# Altium schematic sheet [15] PWR.SchDoc(BLK4)
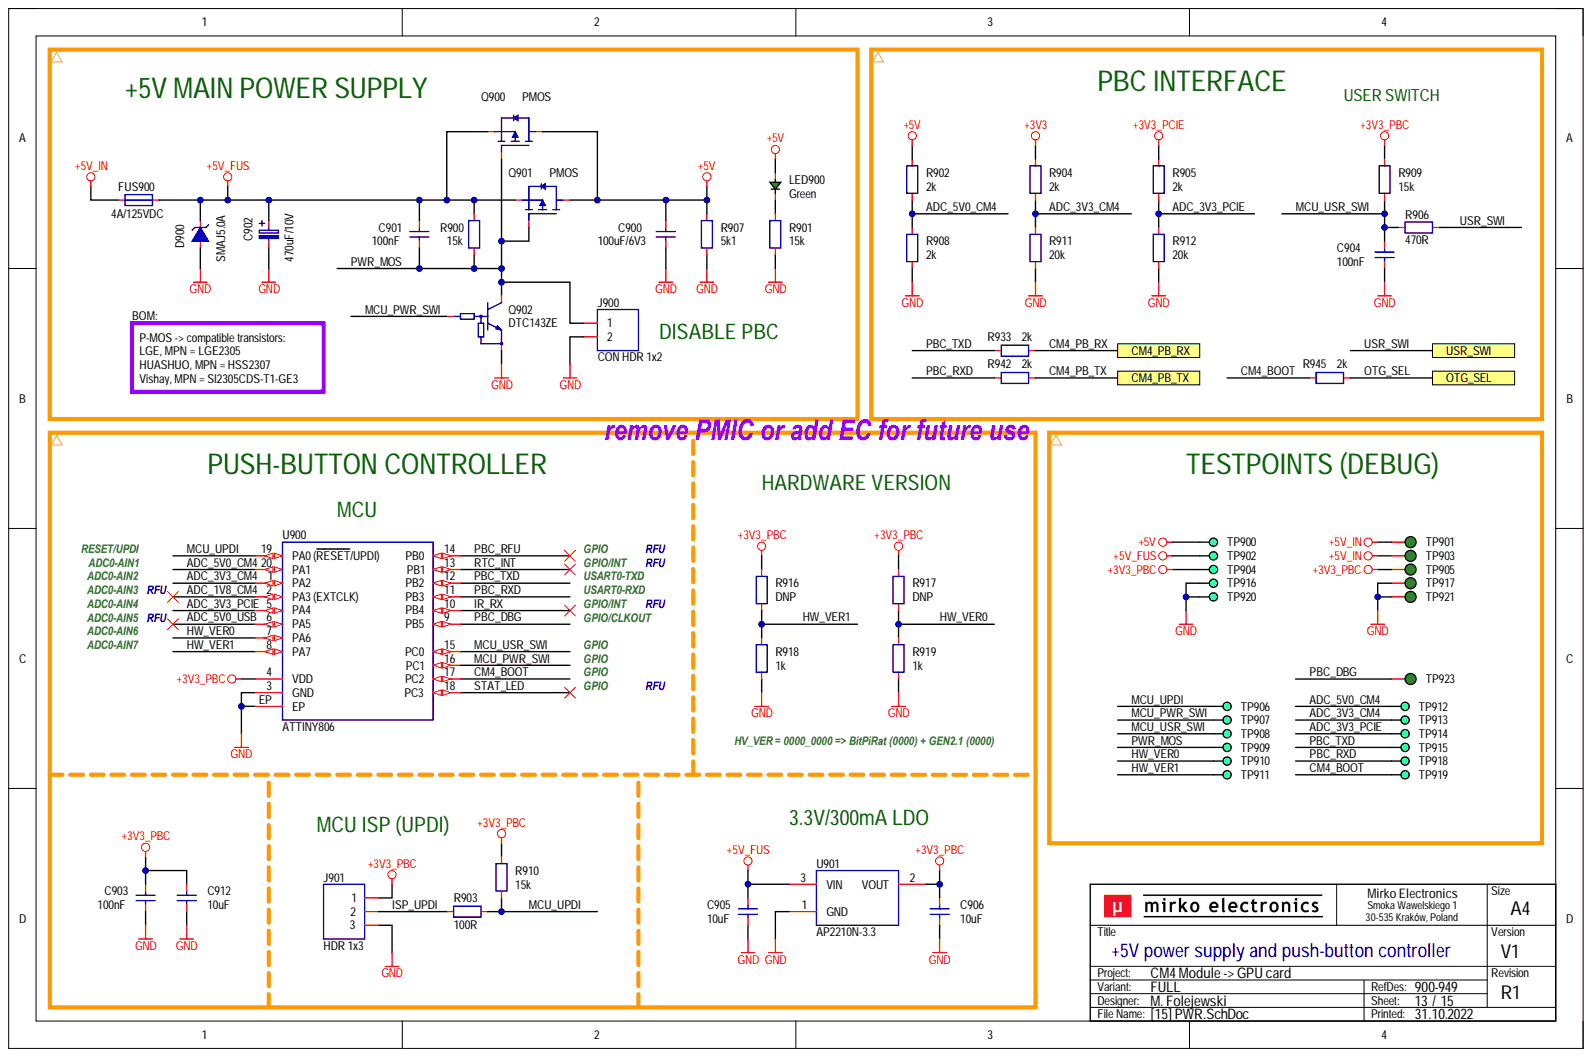
[diagram p0: [15] PWR.SchDoc]

## Parts
C900  100uF/6V3  [CAP_1206_R]
C901  100nF  [CAP_0603_R]
C902  470uF/10V  [CAP_SMD_SIZE_D8]
C903  100nF  [CAP_0603_R]
C904  100nF  [CAP_0603_R]
C905  10uF  [CAP_0603_R]
C906  10uF  [CAP_0603_R]
C912  10uF  [CAP_0603_R]
D900  SMAJ5.0A  [DIO_SMA_UNI_R]
FUS900  4A/125VDC  [FUS_2410_R]
J900  CON HDR 1x2  [CON_HDR_P254_1X02_STR]
J901  HDR 1x3  [CON_HDR_P254_1X03_STR]
LED900  Green  [LED_0805_GRN_R]
Q900  PMOS  [PKG_SOT23-3_TO236]
Q901  PMOS  [PKG_SOT23-3_TO236]
Q902  DTC143ZE  [PKG_SOT523_SC75_SOT416_P100_R]
R900  15k  [RES_0603_R]
R901  15k  [RES_0603_R]
R902  2k  [RES_0603_R]
R903  100R  [RES_0603_R]
R904  2k  [RES_0603_R]
R905  2k  [RES_0603_R]
R906  470R  [RES_0603_R]
R907  5k1  [RES_0603_R]
R908  2k  [RES_0603_R]
R909  15k  [RES_0603_R]
R910  15k  [RES_0603_R]
R911  20k  [RES_0603_R]
R912  20k  [RES_0603_R]
R916  DNP  [RES_0603_R]
R917  DNP  [RES_0603_R]
R918  1k  [RES_0603_R]
R919  1k  [RES_0603_R]
R933  2k  [RES_0603_R]
R942  2k  [RES_0603_R]
R945  2k  [RES_0603_R]
TP900  DEBUG  [PCB_TP_DBG_50]  (value not drawn)  (no BOM)
TP901  ICT  [PCB_TP_ICT_100]  (value not drawn)  (no BOM)
TP902  DEBUG  [PCB_TP_DBG_50]  (value not drawn)  (no BOM)
TP903  ICT  [PCB_TP_ICT_100]  (value not drawn)  (no BOM)
TP904  DEBUG  [PCB_TP_DBG_50]  (value not drawn)  (no BOM)
TP905  ICT  [PCB_TP_ICT_100]  (value not drawn)  (no BOM)
TP906  DEBUG  [PCB_TP_DBG_50]  (value not drawn)  (no BOM)
TP907  DEBUG  [PCB_TP_DBG_50]  (value not drawn)  (no BOM)
TP908  DEBUG  [PCB_TP_DBG_50]  (value not drawn)  (no BOM)
TP909  DEBUG  [PCB_TP_DBG_50]  (value not drawn)  (no BOM)
TP910  DEBUG  [PCB_TP_DBG_50]  (value not drawn)  (no BOM)
TP911  DEBUG  [PCB_TP_DBG_50]  (value not drawn)  (no BOM)
TP912  DEBUG  [PCB_TP_DBG_50]  (value not drawn)  (no BOM)
TP913  DEBUG  [PCB_TP_DBG_50]  (value not drawn)  (no BOM)
TP914  DEBUG  [PCB_TP_DBG_50]  (value not drawn)  (no BOM)
TP915  DEBUG  [PCB_TP_DBG_50]  (value not drawn)  (no BOM)
TP916  DEBUG  [PCB_TP_DBG_50]  (value not drawn)  (no BOM)
TP917  ICT  [PCB_TP_ICT_100]  (value not drawn)  (no BOM)
TP918  DEBUG  [PCB_TP_DBG_50]  (value not drawn)  (no BOM)
TP919  DEBUG  [PCB_TP_DBG_50]  (value not drawn)  (no BOM)
TP920  DEBUG  [PCB_TP_DBG_50]  (value not drawn)  (no BOM)
TP921  ICT  [PCB_TP_ICT_100]  (value not drawn)  (no BOM)
TP923  ICT  [PCB_TP_ICT_100]  (value not drawn)  (no BOM)
U900  ATTINY806  [ICC_QFN20_300X300X90_P40_EP_R]
U901  AP2210N-3.3  [PKG_SOT23-3L_SC59_P190_R]

## Ports
CM4_PB_RX, CM4_PB_TX, OTG_SEL, USR_SWI

## Nets
+3V3: R904.1
+3V3_PBC: C903.2 C906.2 C912.2 J901.1 R909.2 R910.2 R916.2 R917.2 TP904.1 TP905.1 U900.4(VDD) U901.2
+3V3_PCIE: R905.1
+5V: C900.2 LED900.2 Q900.3 Q901.3 R902.1 R907.1 TP900.1
+5V_FUS: C901.2 C902.2 C905.2 D900.1 FUS900.2 Q900.2 Q901.2 R900.2 TP902.1 U901.3
+5V_IN: FUS900.1 TP901.1 TP903.1
GND: C900.1 C902.1 C903.1 C904.1 C905.1 C906.1 C912.1 D900.2 J900.2 J901.3 Q902.2 R901.1 R907.2 R908.2 R911.1 R912.1 R918.1 R919.1 TP916.1 TP917.1 TP920.1 TP921.1 U900.3(GND) U900.EP U901.1
ADC_1V8_CM4: U900.2(PA3_(EXTCLK))
ADC_3V3_CM4: R904.2 R911.2 TP913.1 U900.1(PA2)
ADC_3V3_PCIE: R905.2 R912.2 TP914.1 U900.5(PA4)
ADC_5V0_CM4: R902.2 R908.1 TP912.1 U900.20(PA1)
ADC_5V0_USB: U900.6(PA5)
CM4_BOOT: R945.2 TP919.1 U900.17(PC2)
CM4_PB_RX: R933.2 ; port CM4_PB_RX
CM4_PB_TX: R942.2 ; port CM4_PB_TX
HW_VER0: R917.1 R919.2 TP910.1 U900.7(PA6)
HW_VER1: R916.1 R918.2 TP911.1 U900.8(PA7)
IR_RX: U900.10(PB4)
ISP_UPDI: J901.2 R903.1
MCU_PWR_SWI: Q902.1 TP907.1 U900.16(PC1)
MCU_UPDI: R903.2 R910.1 TP906.1 U900.19(PA0_(RESET/UPDI))
MCU_USR_SWI: C904.2 R906.2 R909.1 TP908.1 U900.15(PC0)
OTG_SEL: R945.1 ; port OTG_SEL
PBC_DBG: TP923.1 U900.9(PB5)
PBC_RFU: U900.14(PB0)
PBC_RXD: R942.1 TP918.1 U900.11(PB3)
PBC_TXD: R933.1 TP915.1 U900.12(PB2)
PWR_MOS: C901.1 J900.1 Q900.1 Q901.1 Q902.3 R900.1 TP909.1
RTC_INT: U900.13(PB1)
STAT_LED: U900.18(PC3)
USR_SWI: R906.1 ; port USR_SWI
NetLED900_1: LED900.1 R901.2

## Library data of the parts
C900 = CAP-0025: Capacitor; MLCC; GENERIC; TBD; TBD; TBD; TBD; SMD; 1206 (3216);; Database Table Name: CAPACITOR$; Component Kind: Standard; Component Subtype: MLCC; Component Type: Capacitor; Manufacturer: GENERIC; Mounting Type: SMD; MPN: GENERIC; Package (Case): 1206 (3216); Supplier: ?; Value: 100uF/6V3; Library Name: MirFol_db_local.DbLib
C901 C903 C904 = CAP-0008: Capacitor; MLCC; 100nF; TBD; TBD; TBD; TBD; SMD; 0603 (1608);; Database Table Name: CAPACITOR$; Component Kind: Standard; Component Subtype: MLCC; Component Type: Capacitor; Manufacturer: TBD; Mounting Type: SMD; MPN: TBD; Package (Case): 0603 (1608); Supplier: ?; Value: 100nF; Library Name: MirFol_db_local.DbLib
C902 = CAP_POL_2P_NP: Capacitor; Polarized;; Manufacturer: Panasonic; MPN: EEEFTA471XAP; Component Subtype: Electrolytic; Package (Case): SMD SIZE D8; Component Kind: Standard; Component Type: Capacitor; Mounting Type: SMD; Value: 470uF/10V; Library Name: MirFol_lib.SchLib
C905 C906 C912 = CAP-0023: Capacitor; MLCC; GENERIC; TBD; TBD; TBD; TBD; SMD; 0603 (1608);; Database Table Name: CAPACITOR$; Component Kind: Standard; Component Subtype: MLCC; Component Type: Capacitor; Manufacturer: GENERIC; Mounting Type: SMD; MPN: GENERIC; Package (Case): 0603 (1608); Supplier: ?; Value: 10uF; Library Name: MirFol_db_local.DbLib
D900 = DIO_TVS_UNI_KA: Diode; Schottky; Unipolar;; Manufacturer: Littelfuse; Component Subtype: TVS Diode; Component Kind: Standard; Component Type: Diode; Mounting Type: SMD; MPN: SMAJ5.0A; Supplier: ?; Value: SMAJ5.0A; Library Name: MirFol_lib.SchLib
FUS900 = FUS: Fuse; Manufacturer: Bel Fuse; MPN: 0679L4000-01; Component Kind: Standard; Value: 4A/125VDC; Library Name: MirFol_lib.SchLib
J900 = CON-0020: Connector; Pin Header; 1 x 2 pins; 2.54mm; Male; TBD; TBD; THT; THT;; Manufacturer: GENERIC; Database Table Name: CONNECTOR$; Component Body: TBD; Component Height: TBD; Component Kind: Standard; Component Subtype: Pin Header; Component Type: Connector; Configuration: 1 x 2 pins; Contact Gender: Male; Contact Plating: TBD; Contact Rating: TBD; Features: TBD; ID: CON-0020; Mounting Type: THT; MPN: GENERIC; Operating Temperature: TBD; Orientation: TBD; Package (Case): THT; Packaging Type: TBD; Pin Count: 2; Pitch: 2.54mm; ROHS Status: TBD; Value: CON HDR 1x2; Library Name: MirFol_db_local.DbLib
J901 = CON_1X03_COL: Connector; 1 Row;; Manufacturer: GENERIC; Component Subtype: Pin Header; Package (Case): THT; Component Kind: Standard; Component Type: Connector; Mounting Type: THT; MPN: GENERIC; Supplier: ?; Value: HDR 1x3; Library Name: MirFol_lib.SchLib
LED900 = DIO_LED_GRN: Diode; LED; Green;; Manufacturer: Foshan NationStar Optoelectronics; MPN: NCD0805G1; Component Subtype: LED Diode; Package (Case): 0805 (2012); Component Kind: Standard; Component Type: LED; Mounting Type: SMD; Supplier: LCSC; Value: Green; Library Name: MirFol_lib.SchLib
Q900 Q901 = TRA_PMOS_GSD: Transistor; PMOS;; Manufacturer: Vishay; MPN: SI2305CDS-T1-GE3; Component Subtype: P-MOSFET; Component Kind: Standard; Component Type: Transistor; Mounting Type: SMD; Supplier: ?; Value: PMOS; Library Name: MirFol_lib.SchLib
Q902 = TRA_BJT_NPN_DIG_BEC: Transistor; BJT; NPN; Digital;; Component Subtype: NPN Transistor; Component Kind: Standard; Component Type: Transistor; Mounting Type: SMD; MPN: DTC143ZE; Supplier: ?; Value: DTC143ZE; Library Name: MirFol_lib.SchLib
R900 R901 R909 R910 = RES-0043: Resistor; Thick Film; 15k; 1%; 100mW; 100PPM; 75V; Standard; SMD; 0603 (1608);; Manufacturer: Yageo Corporation; MPN: TBD; Database Table Name: RESISTOR$; Component Kind: Standard; Component Subtype: Thick Film; Component Type: Resistor; Mounting Type: SMD; Package (Case): 0603 (1608); Supplier: ?; Value: 15k; Library Name: MirFol_db_local.DbLib
R902 R904 R905 R908 R933 R942 R945 = RES-0033: Resistor; Thick Film; 2k2; 1%; 100mW; 100PPM; 75V; Standard; SMD; 0603 (1608);; Manufacturer: Yageo Corporation; MPN: TBD; Database Table Name: RESISTOR$; Component Kind: Standard; Component Subtype: Thick Film; Component Type: Resistor; Mounting Type: SMD; Package (Case): 0603 (1608); Supplier: ?; Value: 2k; Library Name: MirFol_db_local.DbLib
R903 = RES-0026: Resistor; Thick Film; 100R; 1%; 100mW; 100PPM; 75V; Standard; SMD; 0603 (1608);; Manufacturer: Yageo Corporation; MPN: TBD; Database Table Name: RESISTOR$; Component Kind: Standard; Component Subtype: Thick Film; Component Type: Resistor; Mounting Type: SMD; Package (Case): 0603 (1608); Supplier: ?; Value: 100R; Library Name: MirFol_db_local.DbLib
R906 = RES-0042: Resistor; Thick Film; 470R; 1%; 100mW; 100PPM; 75V; Standard; SMD; 0603 (1608);; Manufacturer: Yageo Corporation; MPN: TBD; Database Table Name: RESISTOR$; Component Kind: Standard; Component Subtype: Thick Film; Component Type: Resistor; Mounting Type: SMD; Package (Case): 0603 (1608); Supplier: ?; Value: 470R; Library Name: MirFol_db_local.DbLib
R907 = RES-0043: Resistor; Thick Film; 5k1; 1%; 100mW; 100PPM; 75V; Standard; SMD; 0603 (1608);; Manufacturer: Yageo Corporation; MPN: TBD; Database Table Name: RESISTOR$; Component Kind: Standard; Component Subtype: Thick Film; Component Type: Resistor; Mounting Type: SMD; Package (Case): 0603 (1608); Supplier: ?; Value: 5k1; Library Name: MirFol_db_local.DbLib
R911 R912 = RES-0054: Resistor; Thick Film; GENERIC; TBD; TBD; TBD; TBD; TBD; SMD; 0603 (1608);; Component Body: 1.60 x 0.80mm; Database Table Name: RESISTOR$; Component Height: TBD; Component Kind: Standard; Component Subtype: Thick Film; Component Type: Resistor; Features: TBD; ID: RES-0054; Manufacturer: GENERIC; Mounting Type: SMD; MPN: GENERIC; Operating Temperature: TBD; Package (Case): 0603 (1608); Packaging Type: TBD; Power Rating: TBD; Resistance: 20k; ROHS Status: TBD; TCR: TBD; Tolerance: TBD; Value: 20k; Voltage Rating: TBD; Library Name: MirFol_db_local.DbLib
R916 R917 = RES-0051: Resistor; Thick Film; DNP; TBD; TBD; TBD; TBD; DO NOT PLACE; SMD; 0603 (1608);; Component Body: 1.60 x 0.80mm; Database Table Name: RESISTOR$; Component Height: TBD; Component Kind: Standard; Component Subtype: Thick Film; Component Type: Resistor; Features: DO NOT PLACE; ID: RES-0051; Manufacturer: TBD; Mounting Type: SMD; MPN: TBD; Operating Temperature: TBD; Package (Case): 0603 (1608); Packaging Type: TBD; Power Rating: TBD; Resistance: DNP; ROHS Status: TBD; TCR: TBD; Tolerance: TBD; Value: DNP; Voltage Rating: TBD; Library Name: MirFol_db_local.DbLib
R918 R919 = RES-0027: Resistor; Thick Film; 1k; 1%; 100mW; 100PPM; 75V; Standard; SMD; 0603 (1608);; Manufacturer: Yageo Corporation; MPN: TBD; Database Table Name: RESISTOR$; Component Kind: Standard; Component Subtype: Thick Film; Component Type: Resistor; Mounting Type: SMD; Package (Case): 0603 (1608); Supplier: ?; Value: 1k; Library Name: MirFol_db_local.DbLib
TP900 TP902 TP904 TP906-TP916 TP918-TP920 = PCB_TP_DBG: PCB Marking; Test Point (Debug);; Component Subtype: -; Component Type: Testpoint; Mounting Type: -; Supplier: ?; Value: DEBUG; Library Name: MirFol_lib.SchLib
TP901 TP903 TP905 TP917 TP921 TP923 = PCB_TP_ICT: Test Point (ICT); Specification: Testpoint (for ICT), SMD pad; Component Type: Testpoint (for ICT); Manufacturer: -; Mounting Type: Non component; MPN: -; Value: ICT; Library Name: MirFol_lib.SchLib
U900 = ICC_MCP_ATTINY406_806_1606_VQFN20: IC; MCU;; Manufacturer: Microchip Technology (Atmel); MPN: ATTINY806-MNR; Specification: tinyAVR 8-bit MCU, 20MHz, 8kB flash, 512B RAM, USART, SPI, TWI, VQFN package; Component Kind: Standard; Component Type: IC; Mounting Type: SMD; Operating Temperature: -40°C to +105°C; Package: VQFN20; Value: ATTINY806; Library Name: Pineberry_Lib.SchLib
U901 = ICC_DIO_AP2210N-3.3TR: ICC; LDO regulator; Manufacturer: Diodes Incorporated; MPN: AP2210N-3.3TRG1; Specification: Ultra-low dropout voltage regulator, Vout = 3.3V, 300 mA, SOT23-3 package; Component Kind: Standard; Component Type: IC; Mounting Type: SMD; Operating Temperature: -40°C to +125°C; Package: SOT23-3; Value: AP2210N-3.3; Library Name: Pineberry_Lib.SchLib
